# Altium schematic sheet Sheet1.SchDoc
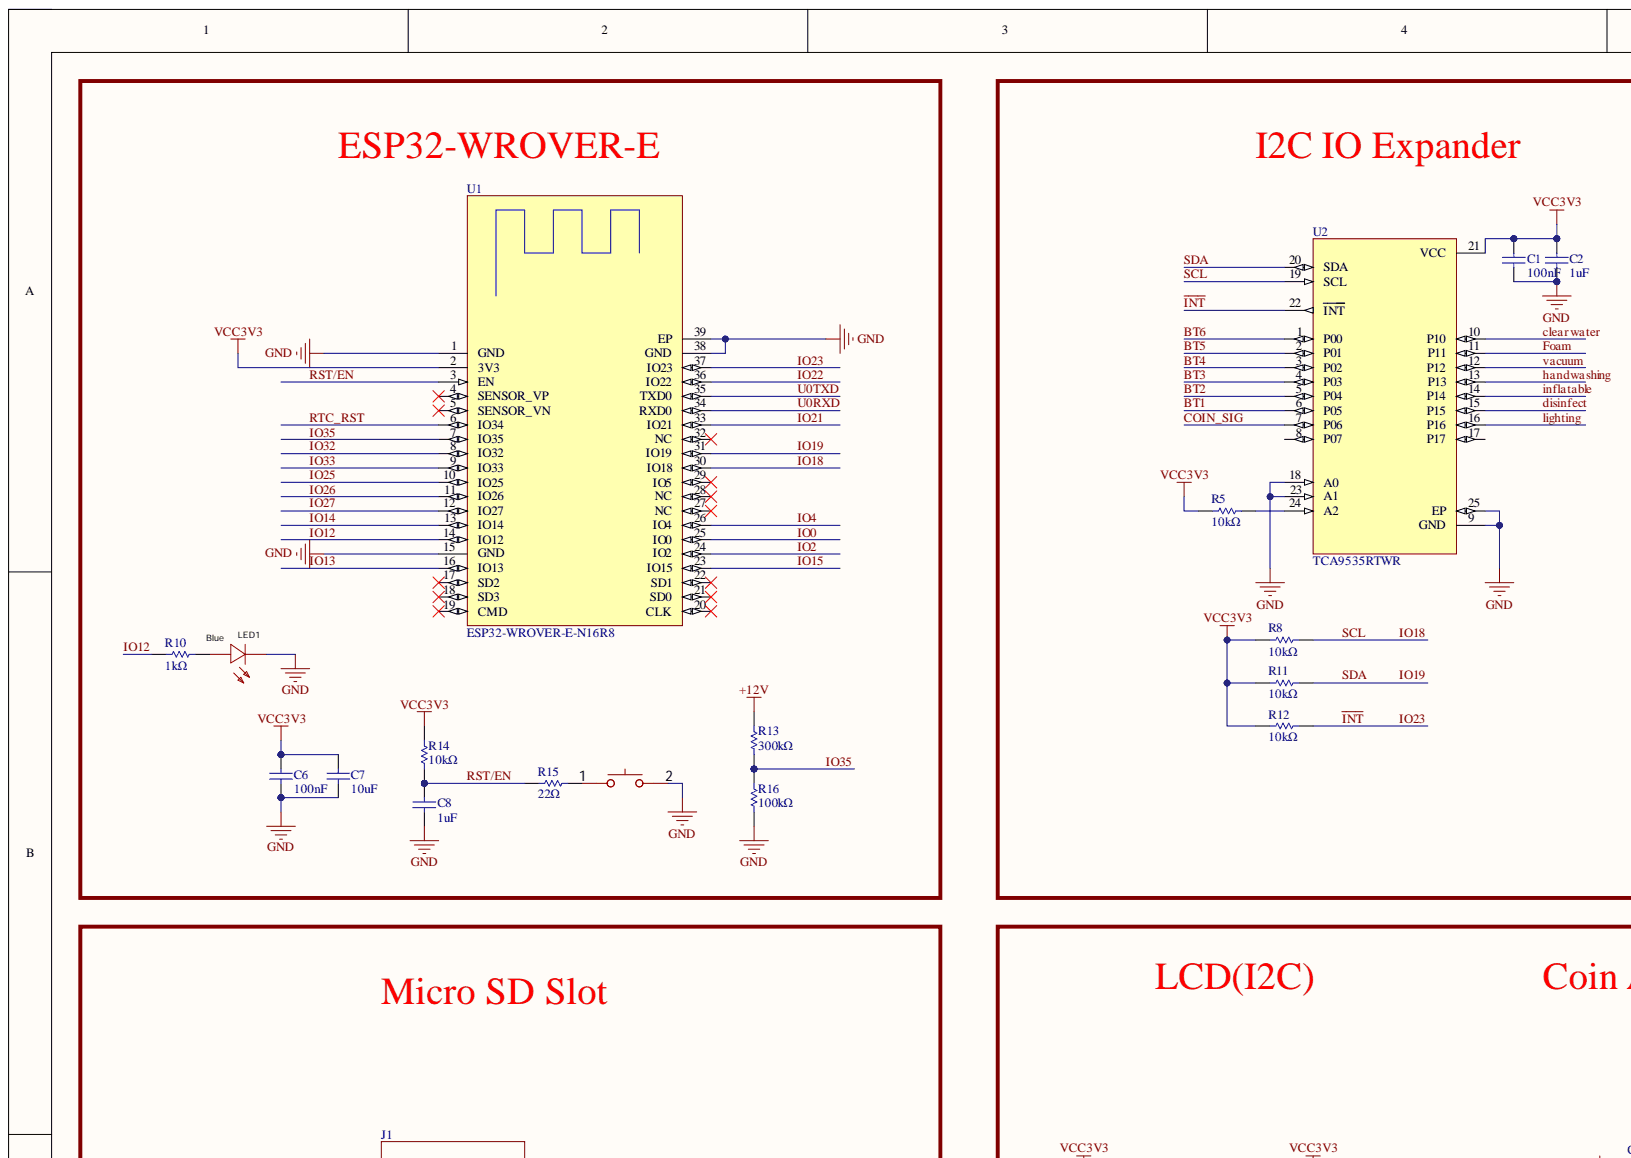
[diagram p0: Sheet1.SchDoc, top left]
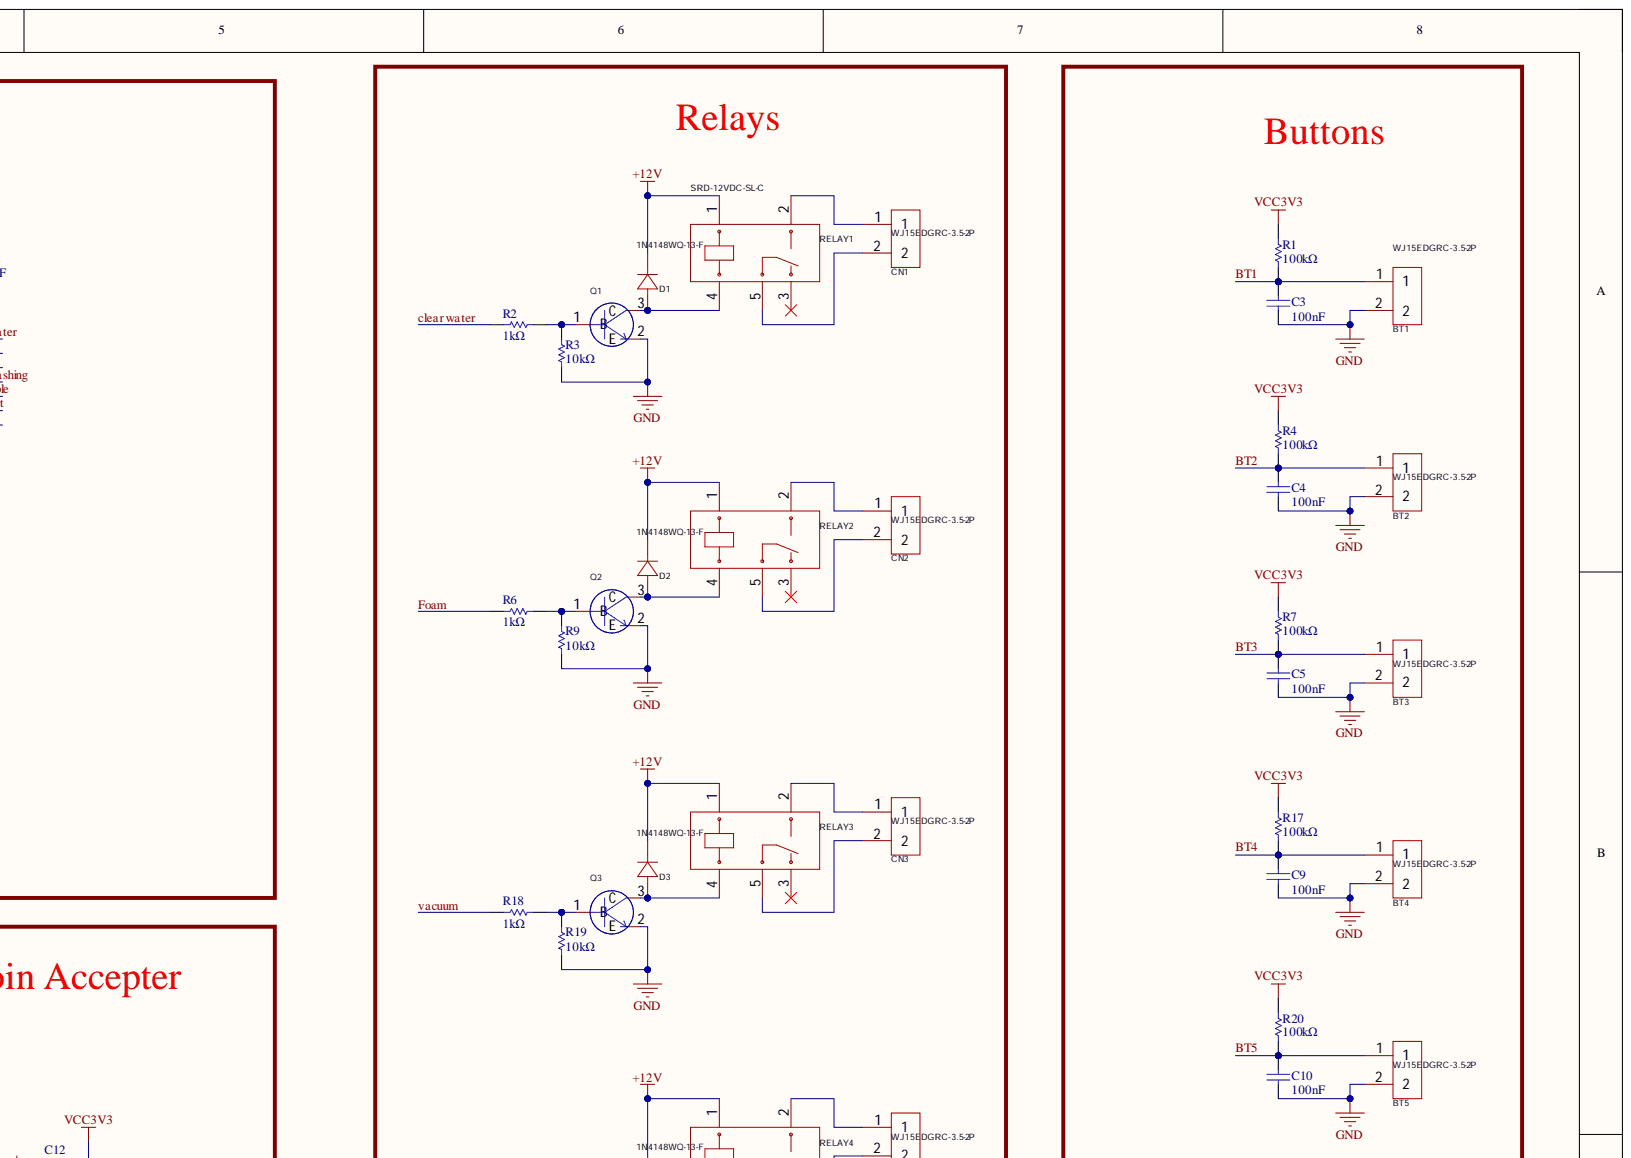
[diagram p1: Sheet1.SchDoc, top right]
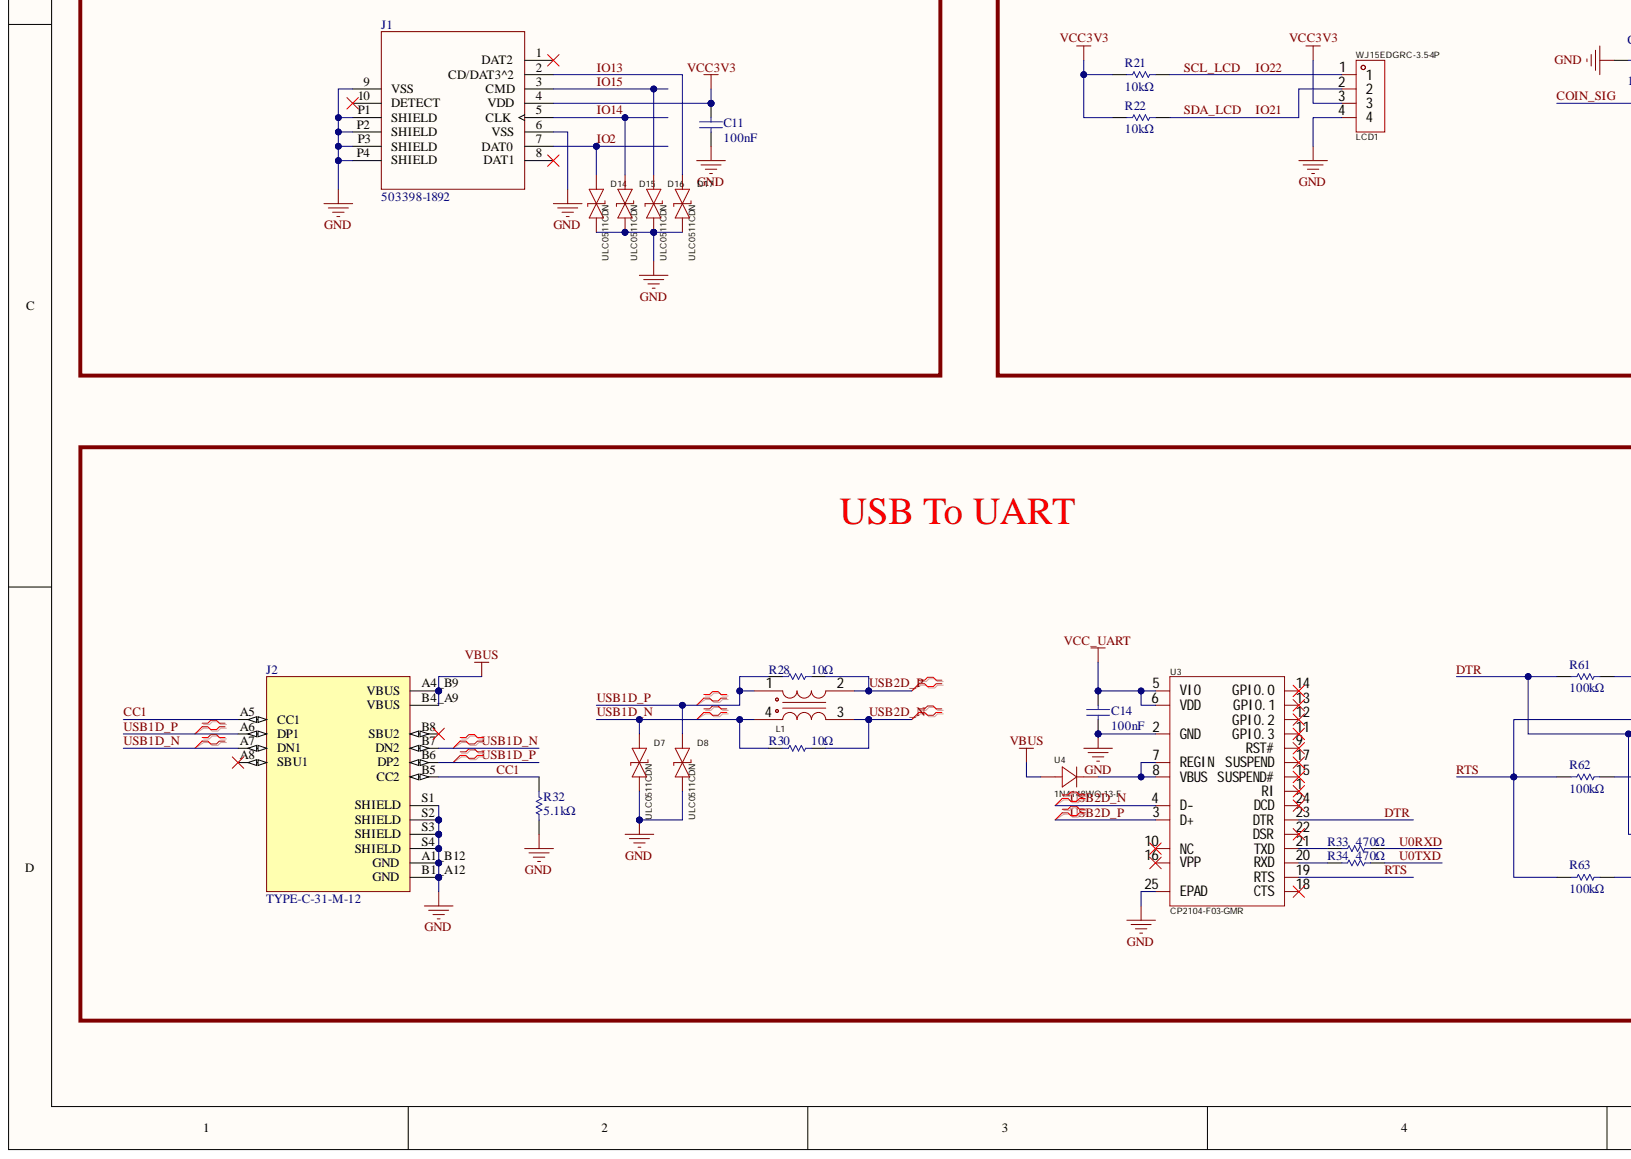
[diagram p2: Sheet1.SchDoc, bottom left]
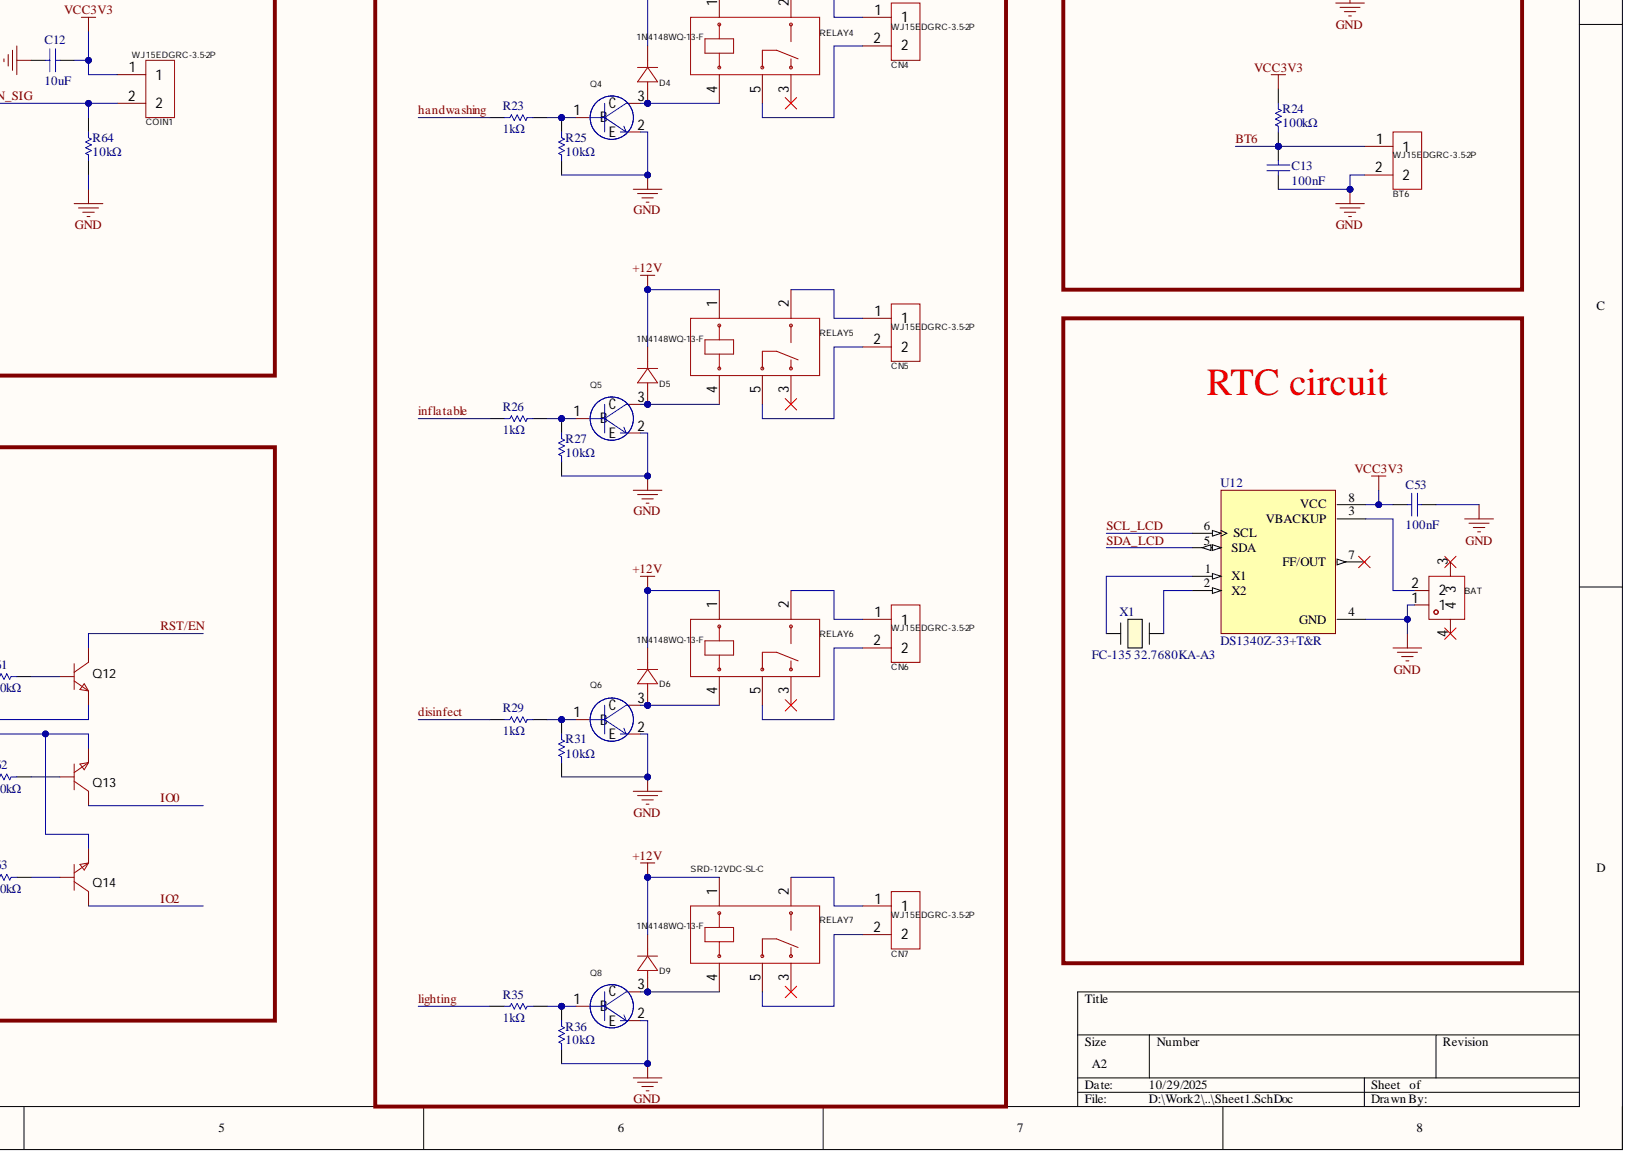
[diagram p3: Sheet1.SchDoc, bottom right]

## Parts
BAT  XUNPU(讯普)'  [CONN-SMD_2P-P1.25_XUNPU_WAFER-MX1.25-2PWB]  (value not drawn)
BT1  WJ15EDGRC-3.5-2P  [CONN-TH_WJ15EDGRC-3.5-2P-14-00A]
BT2  WJ15EDGRC-3.5-2P  [CONN-TH_WJ15EDGRC-3.5-2P-14-00A]
BT3  WJ15EDGRC-3.5-2P  [CONN-TH_WJ15EDGRC-3.5-2P-14-00A]
BT4  WJ15EDGRC-3.5-2P  [CONN-TH_WJ15EDGRC-3.5-2P-14-00A]
BT5  WJ15EDGRC-3.5-2P  [CONN-TH_WJ15EDGRC-3.5-2P-14-00A]
BT6  WJ15EDGRC-3.5-2P  [CONN-TH_WJ15EDGRC-3.5-2P-14-00A]
C1  value 100nF  [C0603]  (hidden comment: Cap)
C2  value 1uF  [C0603]  (hidden comment: Cap)
C3  value 100nF  [C0603]  (hidden comment: Cap)
C4  value 100nF  [C0603]  (hidden comment: Cap)
C5  value 100nF  [C0603]  (hidden comment: Cap)
C6  value 100nF  [C0603]  (hidden comment: Cap)
C7  value 10uF  [C0603]  (hidden comment: Cap)
C8  value 1uF  [C0603]  (hidden comment: Cap)
C9  value 100nF  [C0603]  (hidden comment: Cap)
C10  value 100nF  [C0603]  (hidden comment: Cap)
C11  value 100nF  [C0603]  (hidden comment: Cap)
C12  value 10uF  [C0603]  (hidden comment: Cap)
C13  value 100nF  [C0603]  (hidden comment: Cap)
C14  value 100nF  [C0603]  (hidden comment: Cap)
C53  value 100nF  [C0603]  (hidden comment: Cap)
CN1  WJ15EDGRC-3.5-2P  [CONN-TH_WJ15EDGRC-3.5-2P-14-00A]
CN2  WJ15EDGRC-3.5-2P  [CONN-TH_WJ15EDGRC-3.5-2P-14-00A]
CN3  WJ15EDGRC-3.5-2P  [CONN-TH_WJ15EDGRC-3.5-2P-14-00A]
CN4  WJ15EDGRC-3.5-2P  [CONN-TH_WJ15EDGRC-3.5-2P-14-00A]
CN5  WJ15EDGRC-3.5-2P  [CONN-TH_WJ15EDGRC-3.5-2P-14-00A]
CN6  WJ15EDGRC-3.5-2P  [CONN-TH_WJ15EDGRC-3.5-2P-14-00A]
CN7  WJ15EDGRC-3.5-2P  [CONN-TH_WJ15EDGRC-3.5-2P-14-00A]
COIN1  WJ15EDGRC-3.5-2P  [CONN-TH_WJ15EDGRC-3.5-2P-14-00A]
D1  1N4148WQ-13-F  [SOD-123_L2.7-W1.6-LS3.7-RD]
D2  1N4148WQ-13-F  [SOD-123_L2.7-W1.6-LS3.7-RD]
D3  1N4148WQ-13-F  [SOD-123_L2.7-W1.6-LS3.7-RD]
D4  1N4148WQ-13-F  [SOD-123_L2.7-W1.6-LS3.7-RD]
D5  1N4148WQ-13-F  [SOD-123_L2.7-W1.6-LS3.7-RD]
D6  1N4148WQ-13-F  [SOD-123_L2.7-W1.6-LS3.7-RD]
D7  Leiditech'  [X1-DFN1006-2_L1.0-W0.6-RD]  (value not drawn)
D8  Leiditech'  [X1-DFN1006-2_L1.0-W0.6-RD]  (value not drawn)
D9  1N4148WQ-13-F  [SOD-123_L2.7-W1.6-LS3.7-RD]
D14  Leiditech'  [X1-DFN1006-2_L1.0-W0.6-RD]  (value not drawn)
D15  Leiditech'  [X1-DFN1006-2_L1.0-W0.6-RD]  (value not drawn)
D16  Leiditech'  [X1-DFN1006-2_L1.0-W0.6-RD]  (value not drawn)
D17  Leiditech'  [X1-DFN1006-2_L1.0-W0.6-RD]  (value not drawn)
J1  503398-1892  [MOLEX_503398-1892]
J2  TYPE-C-31-M-12  [HRO_TYPE-C-31-M-12]
L1  744232090  [FILTER-SMD_4P-L3.2-W1.6-TL]  (value not drawn)
LCD1  WJ15EDGRC-3.5-4P  [CONN-TH_4P-P3.50_WJ15EDGRC-3.5]
LED1  value Blue  [LED-SMD_L1.6-W0.8-RD-BLUE]  (hidden comment: EKINGLUX')
Q1  Guangdong Hottech'  [SOT-23-3_L2.9-W1.3-P1.90-LS2.4-BR]  (value not drawn)
Q2  Guangdong Hottech'  [SOT-23-3_L2.9-W1.3-P1.90-LS2.4-BR]  (value not drawn)
Q3  Guangdong Hottech'  [SOT-23-3_L2.9-W1.3-P1.90-LS2.4-BR]  (value not drawn)
Q4  Guangdong Hottech'  [SOT-23-3_L2.9-W1.3-P1.90-LS2.4-BR]  (value not drawn)
Q5  Guangdong Hottech'  [SOT-23-3_L2.9-W1.3-P1.90-LS2.4-BR]  (value not drawn)
Q6  Guangdong Hottech'  [SOT-23-3_L2.9-W1.3-P1.90-LS2.4-BR]  (value not drawn)
Q8  Guangdong Hottech'  [SOT-23-3_L2.9-W1.3-P1.90-LS2.4-BR]  (value not drawn)
Q12  S8050 J3Y(RANGE:200-350)  [SOT-23-3_L2.9-W1.6-P1.90-LS2.8-BR]  (value not drawn)
Q13  S8050 J3Y(RANGE:200-350)  [SOT-23-3_L2.9-W1.6-P1.90-LS2.8-BR]  (value not drawn)
Q14  S8050 J3Y(RANGE:200-350)  [SOT-23-3_L2.9-W1.6-P1.90-LS2.8-BR]  (value not drawn)
R1  value 100kΩ  [R0603]  (hidden comment: Res1)
R2  value 1kΩ  [R0603]  (hidden comment: Res1)
R3  value 10kΩ  [R0603]  (hidden comment: Res1)
R4  value 100kΩ  [R0603]  (hidden comment: Res1)
R5  value 10kΩ  [R0603]  (hidden comment: Res1)
R6  value 1kΩ  [R0603]  (hidden comment: Res1)
R7  value 100kΩ  [R0603]  (hidden comment: Res1)
R8  value 10kΩ  [R0603]  (hidden comment: Res1)
R9  value 10kΩ  [R0603]  (hidden comment: Res1)
R10  value 1kΩ  [R0603]  (hidden comment: Res1)
R11  value 10kΩ  [R0603]  (hidden comment: Res1)
R12  value 10kΩ  [R0603]  (hidden comment: Res1)
R13  value 300kΩ  [R0603]  (hidden comment: Res1)
R14  value 10kΩ  [R0603]  (hidden comment: Res1)
R15  value 22Ω  [R0603]  (hidden comment: Res1)
R16  value 100kΩ  [R0603]  (hidden comment: Res1)
R17  value 100kΩ  [R0603]  (hidden comment: Res1)
R18  value 1kΩ  [R0603]  (hidden comment: Res1)
R19  value 10kΩ  [R0603]  (hidden comment: Res1)
R20  value 100kΩ  [R0603]  (hidden comment: Res1)
R21  value 10kΩ  [R0603]  (hidden comment: Res1)
R22  value 10kΩ  [R0603]  (hidden comment: Res1)
R23  value 1kΩ  [R0603]  (hidden comment: Res1)
R24  value 100kΩ  [R0603]  (hidden comment: Res1)
R25  value 10kΩ  [R0603]  (hidden comment: Res1)
R26  value 1kΩ  [R0603]  (hidden comment: Res1)
R27  value 10kΩ  [R0603]  (hidden comment: Res1)
R28  value 10Ω  [R0603]  (hidden comment: Res1)
R29  value 1kΩ  [R0603]  (hidden comment: Res1)
R30  value 10Ω  [R0603]  (hidden comment: Res1)
R31  value 10kΩ  [R0603]  (hidden comment: Res1)
R32  value 5.1kΩ  [R0603]  (hidden comment: Res1)
R33  value 470Ω  [R0603]  (hidden comment: Res1)
R34  value 470Ω  [R0603]  (hidden comment: Res1)
R35  value 1kΩ  [R0603]  (hidden comment: Res1)
R36  value 10kΩ  [R0603]  (hidden comment: Res1)
R61  value 100kΩ  [R0603]  (hidden comment: Res1)
R62  value 100kΩ  [R0603]  (hidden comment: Res1)
R63  value 100kΩ  [R0603]  (hidden comment: Res1)
R64  value 10kΩ  [R0603]  (hidden comment: Res1)
RELAY1  SRD-12VDC-SL-C  [RELAY-TH_SRD-XXVDC-XL-C]
RELAY2  SRD-12VDC-SL-C  [RELAY-TH_SRD-XXVDC-XL-C]
RELAY3  SRD-12VDC-SL-C  [RELAY-TH_SRD-XXVDC-XL-C]
RELAY4  SRD-12VDC-SL-C  [RELAY-TH_SRD-XXVDC-XL-C]
RELAY5  SRD-12VDC-SL-C  [RELAY-TH_SRD-XXVDC-XL-C]
RELAY6  SRD-12VDC-SL-C  [RELAY-TH_SRD-XXVDC-XL-C]
RELAY7  SRD-12VDC-SL-C  [RELAY-TH_SRD-XXVDC-XL-C]
SW1  ALPSALPINE'  [SW-SMD_L3.9-W3.0-P4.45]  (value not drawn)
U1  ESP32-WROVER-E-N16R8  [XCVR_ESP32-WROVER-B_(16MB)]
U2  TCA9535RTWR  [QFN50P400X400X80-25N]
U3  CP2104-F03-GMR  [QFN-24_L4.0-W4.0-P0.50-BL-EP2.7]
U4  1N4148WQ-13-F  [SOD-123_L2.7-W1.6-LS3.7-RD]
U12  DS1340Z-33+T&R  [SOIC127P600X175-8N]
X1  FC-135 32.7680KA-A3  [XTAL_FC-135R_EPS]

## Nets
+12V: D1.1 D2.1 D3.1 D4.1 D5.1 D6.1 D9.1 R13.2 RELAY1.1 RELAY2.1 RELAY3.1 RELAY4.1 RELAY5.1 RELAY6.1 RELAY7.1
GND: BAT.1 BT1.2 BT2.2 BT3.2 BT4.2 BT5.2 BT6.2 C1.1 C2.1 C3.1 C4.1 C5.1 C6.1 C7.1 C8.1 C9.1 C10.1 C11.1 C12.2 C13.1 C14.1 C53.1 D7.1 D8.1 D14.1 D15.1 D16.1 D17.1 J1.6(VSS) J1.9(VSS) J1.P1(SHIELD) J1.P2(SHIELD) J1.P3(SHIELD) J1.P4(SHIELD) J2.A1_B12(GND) J2.B1_A12(GND) J2.S1(SHIELD) J2.S2(SHIELD) J2.S3(SHIELD) J2.S4(SHIELD) LCD1.4 LED1.1 Q1.2 Q2.2 Q3.2 Q4.2 Q5.2 Q6.2 Q8.2 R3.2 R9.2 R16.1 R19.2 R25.2 R27.2 R31.2 R32.1 R36.2 R64.2 SW1.2 U1.1(GND) U1.15(GND) U1.38(GND) U1.39(EP) U2.9(GND) U2.18(A0) U2.23(A1) U2.25(EP) U3.2(GND) U3.25(EPAD) U12.4(GND)
VBUS: J2.A4_B9(VBUS) J2.B4_A9(VBUS) U4.2
VCC3V3: C1.2 C2.2 C6.2 C7.2 C11.2 C12.1 C53.2 COIN1.1 J1.4(VDD) LCD1.3 R1.2 R4.2 R5.2 R7.2 R8.2 R11.2 R12.2 R14.2 R17.2 R20.2 R21.2 R22.2 R24.2 U1.2(3V3) U2.21(VCC) U12.8(VCC)
VCC_UART: C14.2 U3.5(VIO) U3.6(VDD)
BT1: BT1.1 C3.2 R1.1 U2.6(P05)
BT2: BT2.1 C4.2 R4.1 U2.5(P04)
BT3: BT3.1 C5.2 R7.1 U2.4(P03)
BT4: BT4.1 C9.2 R17.1 U2.3(P02)
BT5: BT5.1 C10.2 R20.1 U2.2(P01)
BT6: BT6.1 C13.2 R24.1 U2.1(P00)
CC1: J2.A5(CC1) J2.B5(CC2) R32.2
clear water: R2.1 U2.10(P10)
COIN_SIG: COIN1.2 R64.1 U2.7(P06)
disinfect: R29.1 U2.15(P15)
DTR: Q13.2 Q14.2 R61.2 U3.23(DTR)
Foam: R6.1 U2.11(P11)
handwashing: R23.1 U2.13(P13)
~{INT}: R12.1 U1.37(IO23) U2.22(INT)
inflatable: R26.1 U2.14(P14)
IO0: Q13.3 U1.25(IO0)
IO2: D14.2 J1.7(DAT0) Q14.3 U1.24(IO2)
IO4: U1.26(IO4)
IO12: R10.1 U1.14(IO12)
IO13: D17.2 J1.2(CD/DAT3^2) U1.16(IO13)
IO14: D15.2 J1.5(CLK) U1.13(IO14)
IO15: D16.2 J1.3(CMD) U1.23(IO15)
IO18: R8.1 U1.30(IO18) U2.19(SCL)
IO19: R11.1 U1.31(IO19) U2.20(SDA)
IO21: LCD1.2 R22.1 U1.33(IO21) U12.5(SDA)
IO22: LCD1.1 R21.1 U1.36(IO22) U12.6(SCL)
IO25: U1.10(IO25)
IO26: U1.11(IO26)
IO27: U1.12(IO27)
IO32: U1.8(IO32)
IO33: U1.9(IO33)
IO35: R13.1 R16.2 U1.7(IO35)
lighting: R35.1 U2.16(P16)
RST/EN: C8.2 Q12.3 R14.1 R15.2 U1.3(EN)
RTC_RST: U1.6(IO34)
RTS: Q12.2 R62.2 R63.2 U3.19(RTS)
U0RXD: R33.1 U1.34(RXD0)
U0TXD: R34.1 U1.35(TXD0)
USB1D_N: D7.2 J2.A7(DN1) J2.B7(DN2) L1.4 R30.2
USB1D_P: D8.2 J2.A6(DP1) J2.B6(DP2) L1.1 R28.2
USB2D_N: L1.3 R30.1 U3.4(D-)
USB2D_P: L1.2 R28.1 U3.3(D+)
vacuum: R18.1 U2.12(P12)
NetBAT_2: BAT.2 U12.3(VBACKUP)
NetCN1_1: CN1.1 RELAY1.2
NetCN1_2: CN1.2 RELAY1.5
NetCN2_1: CN2.1 RELAY2.2
NetCN2_2: CN2.2 RELAY2.5
NetCN3_1: CN3.1 RELAY3.2
NetCN3_2: CN3.2 RELAY3.5
NetCN4_1: CN4.1 RELAY4.2
NetCN4_2: CN4.2 RELAY4.5
NetCN5_1: CN5.1 RELAY5.2
NetCN5_2: CN5.2 RELAY5.5
NetCN6_1: CN6.1 RELAY6.2
NetCN6_2: CN6.2 RELAY6.5
NetCN7_1: CN7.1 RELAY7.2
NetCN7_2: CN7.2 RELAY7.5
NetD1_2: D1.2 Q1.3 RELAY1.4
NetD2_2: D2.2 Q2.3 RELAY2.4
NetD3_2: D3.2 Q3.3 RELAY3.4
NetD4_2: D4.2 Q4.3 RELAY4.4
NetD5_2: D5.2 Q5.3 RELAY5.4
NetD6_2: D6.2 Q6.3 RELAY6.4
NetD9_2: D9.2 Q8.3 RELAY7.4
NetLED1_2: LED1.2 R10.2
NetQ1_1: Q1.1 R2.2 R3.1
NetQ2_1: Q2.1 R6.2 R9.1
NetQ3_1: Q3.1 R18.2 R19.1
NetQ4_1: Q4.1 R23.2 R25.1
NetQ5_1: Q5.1 R26.2 R27.1
NetQ6_1: Q6.1 R29.2 R31.1
NetQ8_1: Q8.1 R35.2 R36.1
NetQ12_1: Q12.1 R61.1
NetQ13_1: Q13.1 R62.1
NetQ14_1: Q14.1 R63.1
NetR5_1: R5.1 U2.24(A2)
NetR15_1: R15.1 SW1.1
NetR33_2: R33.2 U3.21(TXD)
NetR34_2: R34.2 U3.20(RXD)
NetU3_7: U3.7(REGIN) U3.8(VBUS) U4.1
NetU12_1: U12.1(X1) X1.1
NetU12_2: U12.2(X2) X1.2

Not connected: BAT 3 4; J1 1(DAT2) 8(DAT1) 10(DETECT); J2 A8(SBU1) B8(SBU2); RELAY1 3; RELAY2 3; RELAY3 3; RELAY4 3; RELAY5 3; RELAY6 3; RELAY7 3; U1 4(SENSOR_VP) 5(SENSOR_VN) 17(SD2) 18(SD3) 19(CMD) 20(CLK) 21(SD0) 22(SD1) 27(NC) 28(NC) 29(IO5) 32(NC); U2 8(P07) 17(P17); U3 1(RI) 9(RST#) 10(NC) 11(GPIO.3) 12(GPIO.2) 13(GPIO.1) 14(GPIO.0) 15(SUSPEND#) 16(VPP) 17(SUSPEND) 18(CTS) 22(DSR) 24(DCD); U12 7(FF/OUT)

## Library data of the parts
BAT = WAFER-MX1.25-2PWB: Value: 1x2P; Component Kind: Standard; LCSC Part #: C3029359; Library Name: Schlib1.SchLib; Manufacturer: XUNPU(讯普); Mfr. Part #: WAFER-MX1.25-2PWB; Package: SMD,P=1.25mm,卧贴
BT1-BT6 CN1-CN7 COIN1 = WJ15EDGRC-3.5-2P_C61791: https://es.aliexpress.com/item/1005005511990041.html?spm=a2g0o.productlist.main.3.444dHbQMHbQMQW&algo_pvid=eebe03df-903d-4a01-8bcf-3ccdb62df07f&algo_exp_id=eebe03df-903d-4a01-8bcf-3ccdb62df07f-1&pdp_ext_f=%7B%22order%22%3A%221248%22%2C%22eval%22%3A%221%22%7D&pdp_npi=4%40dis%21EUR%212.01%211.89%21%21%212.04%211.92%21%402103890917374691080141047e4ab9%2112000033379333548%21sea%21DE%21709413394%21X&curPageLogUid=aJgEfep2J8j6&utparam-url=scene%3Asearch%7Cquery_from%3A; Manufacturer: Ningbo Kangnex Elec; Value: 2P; Component Kind: Standard; LCSC Part #: C61791; Library Name: Schlib1.SchLib; Mfr. Part #: WJ15EDGRC-3.5-2P; Package: Push-Pull,P=3.5mm; PCB3D: CONN-TH_WJ15EDGRC-3.5-2P-14-00A
C1 C3-C6 C9-C11 C13 C14 C53 = Cap: Capacitor; Manufacturer: YAGEO; Mfr. Part #: CC0603KRX7R9BB104; C14663: 0603; Component Kind: Standard; LCSC Part #: C14663; Library Name: Miscellaneous Devices.IntLib; Signal Integrity: Cap; Simulation: CAP
C2 C8 = Cap: Capacitor; Manufacturer: Samsung Electro-Mechanics; Mfr. Part #: CL10A105KO8NNNC; C14663: 0603; Component Kind: Standard; LCSC Part #: C1592; Library Name: Miscellaneous Devices.IntLib; Signal Integrity: Cap; Simulation: CAP
C7 C12 = Cap: Capacitor; Manufacturer: Samsung Electro-Mechanics; Mfr. Part #: CL10A106MA8NRNC; C14663: 0603; Component Kind: Standard; LCSC Part #: C96446; Library Name: Miscellaneous Devices.IntLib; Signal Integrity: Cap; Simulation: CAP
D1-D6 D9 U4 = 1N4148WQ-13-F: Manufacturer: Diodes Incorporated; Value: 1N4148; Component Kind: Standard; LCSC Part #: C3151708; Library Name: Schlib1.SchLib; Mfr. Part #: 1N4148WQ-13-F; Package: SOD-123; PCB3D: SOD-123_L2.7-W1.6-H1.4-LS3.7
D7 D8 D14-D17 = ULC0511CDN: Component Kind: Standard; LCSC Part #: C384841; Library Name: Schlib1.SchLib; Manufacturer: Leiditech; Mfr. Part #: ULC0511CDN; Package: X1-DFN1006-2
J1 = 503398-1892: 9 (8 + 1) Position Card Connector Secure Digital - microSDâ„¢ Surface Mount, Right Angle Gold; Manufacturer: MOLEX; Mfr. Part #: 5033981892; Component Kind: Standard; LCSC Part #: C428492; Library Name: Schlib1.SchLib; Package: SMD
J2 = TYPE-C-31-M-12: USB Connectors 24 Receptacle 1 8.94*7.3mm RoHS; Manufacturer: Korean Hroparts Elec; Component Kind: Standard; LCSC Part #: C165948; Library Name: Schlib1.SchLib; Mfr. Part #: TYPE-C-31-M-12; Package: Type-C
L1 = 744232090: Manufacturer: Wurth(伍尔特); Value: 90 Ω @ 100MHz 370mA; Component Kind: Standard; JLCPCB Part #: C2649327; Library Name: Schlib1.SchLib; MFR.Part #: 744232090; Package: 1206; PCB3D: FILTER-SMD_4P-L3.2-W1.6-H1.8-TL
LCD1 = WJ15EDGRC-3.5-4P: https://es.aliexpress.com/item/1005005511990041.html?spm=a2g0o.productlist.main.3.444dHbQMHbQMQW&algo_pvid=eebe03df-903d-4a01-8bcf-3ccdb62df07f&algo_exp_id=eebe03df-903d-4a01-8bcf-3ccdb62df07f-1&pdp_ext_f=%7B%22order%22%3A%221248%22%2C%22eval%22%3A%221%22%7D&pdp_npi=4%40dis%21EUR%212.01%211.89%21%21%212.04%211.92%21%402103890917374691080141047e4ab9%2112000033379333548%21sea%21DE%21709413394%21X&curPageLogUid=aJgEfep2J8j6&utparam-url=scene%3Asearch%7Cquery_from%3A; Manufacturer: DORABO; Mfr. Part #: DB2ERC-3.5-4P-GN; Value: 4P; Component Kind: Standard; LCSC Part #: C395831; Library Name: Schlib1.SchLib; Package: Push-Pull,P=3.5mm; PCB3D: CONN-TH_4P-P3.50_WJ15EDGRC-3.5
LED1 = E6Q0603QBAC1UDA: Component Kind: Standard; LCSC Part #: C375575; Library Name: Schlib1.SchLib; Manufacturer: EKINGLUX; Mfr. Part #: E6Q0603QBAC1UDA; Package: 0603
Q1-Q6 Q8 = 2SC3356_C193010: Value: NPN; Component Kind: Standard; LCSC Part #: C193010; Library Name: Schlib1.SchLib; Manufacturer: Guangdong Hottech; Mfr. Part #: 2SC3356; Package: SOT-23
Q12-Q14 = S8050 J3Y(RANGE:200-350): Manufacturer: Jiangsu Changjing Electronics Technology Co., Ltd.; Value: NPN; Component Kind: Standard; LCSC Part #: C2146; Library Name: Schlib1.SchLib; Mfr. Part #: S8050 J3Y(RANGE:200-350); Package: SOT-23
R1 R4 R7 R16 R17 R20 R24 R61-R63 = Res1: Resistor; Manufacturer: UNI-ROYAL(Uniroyal Elec); Mfr. Part #: 0603WAJ0104T5E; Component Kind: Standard; LCSC Part #: C15458; Library Name: Miscellaneous Devices.IntLib; Package: 0603; Signal Integrity: Res; Simulation: RESISTOR
R2 R6 R10 R18 R23 R26 R29 R35 = Res1: Resistor; Manufacturer: UNI-ROYAL(Uniroyal Elec); Mfr. Part #: 0603WAF1001T5E; Component Kind: Standard; LCSC Part #: C21190; Library Name: Miscellaneous Devices.IntLib; Package: 0603; Signal Integrity: Res; Simulation: RESISTOR
R3 R5 R8 R9 R11 R12 R14 R19 R21 R22 R25 R27 R31 R36 R64 = Res1: Resistor; Manufacturer: UNI-ROYAL(Uniroyal Elec); Mfr. Part #: 0603WAJ0103T5E; Component Kind: Standard; LCSC Part #: C15401; Library Name: Miscellaneous Devices.IntLib; Package: 0603; Signal Integrity: Res; Simulation: RESISTOR
R13 = Res1: Resistor; Manufacturer: FH (Guangdong Fenghua Advanced Tech); Mfr. Part #: RS-03K304JT; Component Kind: Standard; LCSC Part #: C73807; Library Name: Miscellaneous Devices.IntLib; Package: 0603; Signal Integrity: Res; Simulation: RESISTOR
R15 = Res1: Resistor; Manufacturer: UNI-ROYAL(Uniroyal Elec); Mfr. Part #: 0603WAJ0220T5E; Component Kind: Standard; LCSC Part #: C1203; Library Name: Miscellaneous Devices.IntLib; Package: 0603; Signal Integrity: Res; Simulation: RESISTOR
R28 R30 = Res1: Resistor; Manufacturer: UNI-ROYAL(Uniroyal Elec); Mfr. Part #: 0603WAF100JT5E; Component Kind: Standard; LCSC Part #: C22859; Library Name: Miscellaneous Devices.IntLib; Package: 0603; Signal Integrity: Res; Simulation: RESISTOR
R32 = Res1: Resistor; Manufacturer: UNI-ROYAL(Uniroyal Elec); Mfr. Part #: 0603WAF5101T5E; Component Kind: Standard; LCSC Part #: C23186; Library Name: Miscellaneous Devices.IntLib; Package: 0603; Signal Integrity: Res; Simulation: RESISTOR
R33 R34 = Res1: Resistor; Manufacturer: UNI-ROYAL(Uniroyal Elec); Mfr. Part #: 0603WAF4700T5E; Component Kind: Standard; LCSC Part #: C23179; Library Name: Miscellaneous Devices.IntLib; Package: 0603; Signal Integrity: Res; Simulation: RESISTOR
RELAY1-RELAY7 = SRD-12VDC-SL-C: Manufacturer: Ningbo Songle Relay; Value: 12V; Component Kind: Standard; LCSC Part #: C30431; Library Name: Schlib1.SchLib; Mfr. Part #: SRD-12VDC-SL-C; Package: Plugin,15.6x19.2mm; PCB3D: RELAY-TH_L19.2-W15.6-H15.8
SW1 = SKRKAEE020: Component Kind: Standard; LCSC Part #: C115357; Library Name: Schlib1.SchLib; Manufacturer: ALPSALPINE; Mfr. Part #: SKRKAEE020; Package: SMD; Value: -
U1 = ESP32-WROVER-B_(16MB): Bluetooth, WiFi 802.11b/g/n, Bluetooth v4.2 +EDR Transceiver Module 2.4GHz ~ 2.5GHz PCB Trace Surface Mount; Manufacturer: Espressif Systems; Component Kind: Standard; LCSC Part #: C529589; Library Name: Schlib1.SchLib; Mfr. Part #: ESP32-WROVER-E-N16R8; Package: SMD,18x31.4mm
U2 = TCA9535RTWR: Manufacturer: Texas Instruments; Value: I2C GPIO Expander; Component Kind: Standard; JLCPCB Part #: C129354; Library Name: Schlib1.SchLib; MFR.Part #: TCA9535RTWR; Package: WQFN-24-EP(4x4) Copy
U3 = CP2104-F03-GMR_C47742: Manufacturer: SKYWORKS/SILICON LABS; Value: USB to Uart; Component Kind: Standard; JLCPCB Part #: C47742; Library Name: Schlib1.SchLib; MFR.Part #: CP2104-F03-GMR; Package: QFN-24-EP(4x4); PCB3D: QFN-24_L4.0-W4.0-P0.50-BL-EP2.7
U12 = DS1340Z-33+T&R: Real Time Clock (RTC) IC Clock/Calendar IÂ²C, 2-Wire Serial 8-SOIC (0.154, 3.90mm Width); Manufacturer: Maxim Integrated; MFR.Part #: DS1340Z-33+T&amp;R; Component Kind: Standard; LCSC Part #: C124671; Library Name: Schlib1.SchLib; Package: SOIC-8 Maxim
X1 = FC-135 32.7680KA-A3: SMD Crystal 32.768KHz-12.5pF-20ppm-2P-3.2*1.5*0.9mm; Manufacturer: Epson; Component Kind: Standard; LCSC Part#: C32346; Library Name: Schlib1.SchLib; MFR.Part #: FC-135 32.7680KA-A3; Package: SMD3215-2P; Value: 32.768Khz
